# Altium schematic sheet CONNECTOR.SchDoc
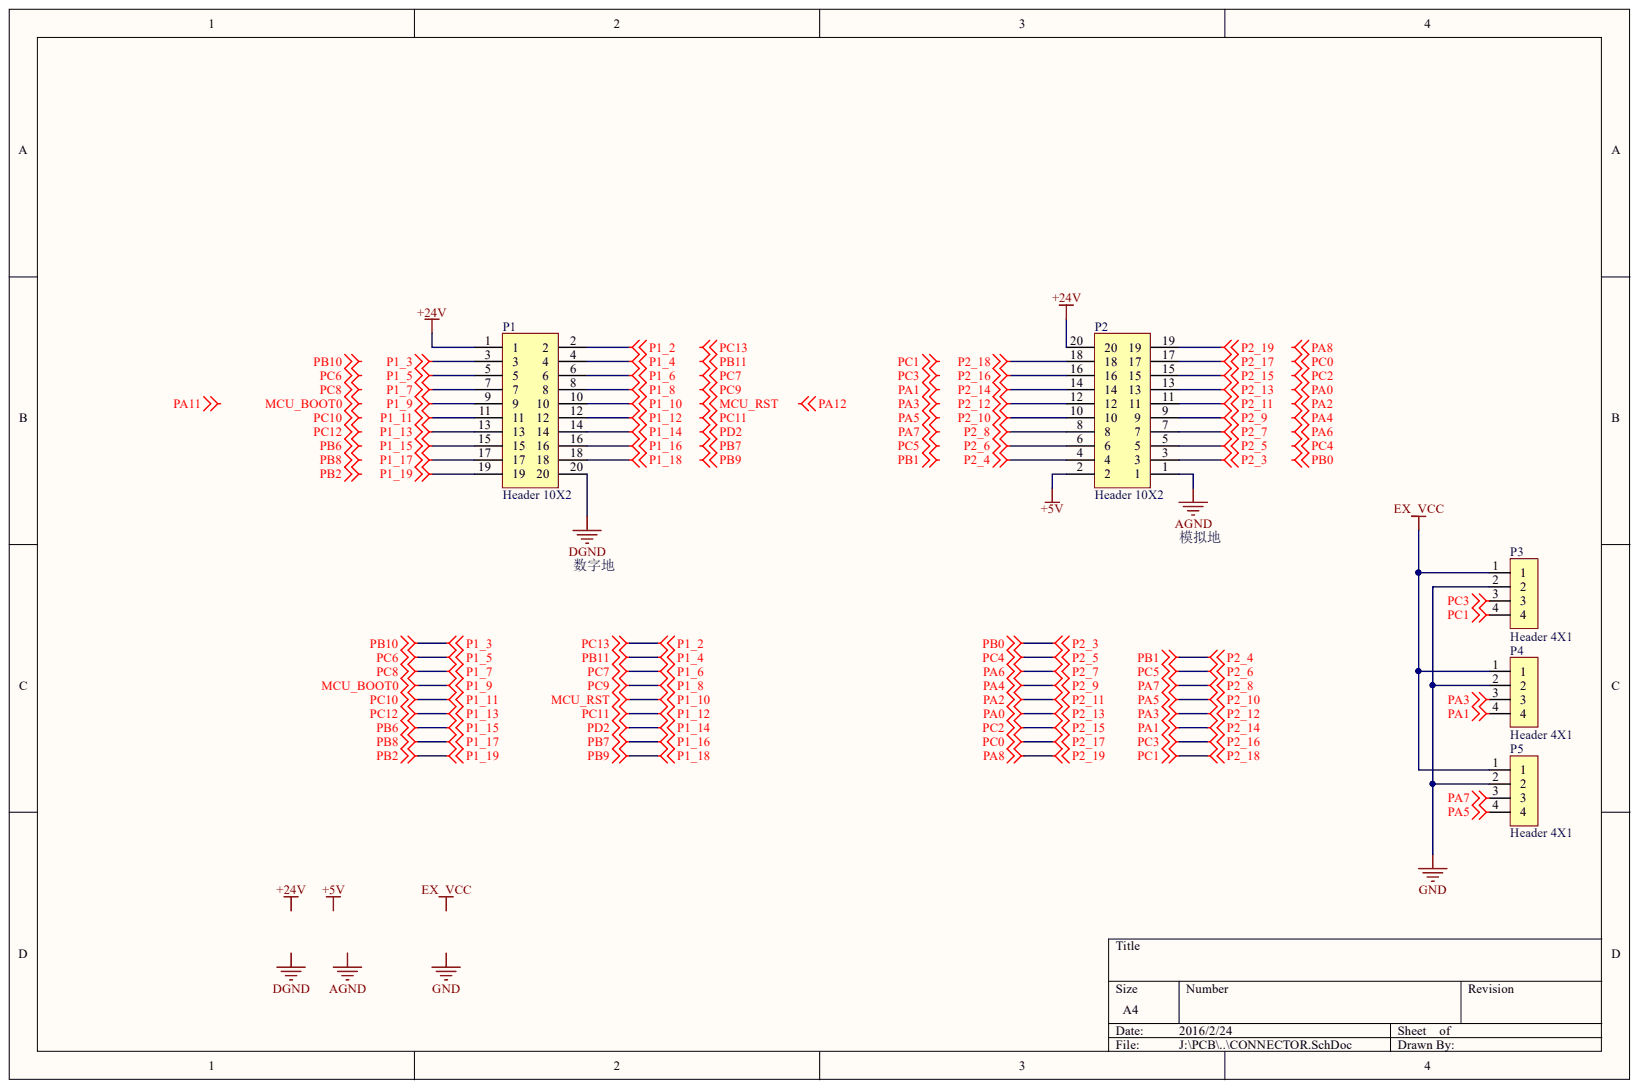
[diagram p0: CONNECTOR.SchDoc]

## Parts
P1  Header 10X2  [Header 2*10]
P2  Header 10X2  [Header 2*10]
P3  Header 4X1  [Header 4X1]
P4  Header 4X1  [Header 4X1]
P5  Header 4X1  [Header 4X1]

## Nets
+5V: P2.2
+24V: P1.1 P2.20
AGND: P2.1
DGND: P1.20
EX_VCC: P3.1 P4.1 P5.1
GND: P3.2 P4.2 P5.2
MCU_BOOT0: P1.9
MCU_RST: P1.10
P1_2: P1.2
P1_3: P1.3
P1_4: P1.4
P1_5: P1.5
P1_6: P1.6
P1_7: P1.7
P1_8: P1.8
P1_11: P1.11
P1_12: P1.12
P1_13: P1.13
P1_14: P1.14
P1_15: P1.15
P1_16: P1.16
P1_17: P1.17
P1_18: P1.18
P1_19: P1.19
P2_3: P2.3
P2_4: P2.4
P2_5: P2.5
P2_6: P2.6
P2_7: P2.7
P2_8: P2.8 P5.3
P2_9: P2.9
P2_10: P2.10 P5.4
P2_11: P2.11
P2_12: P2.12 P4.3
P2_13: P2.13
P2_14: P2.14 P4.4
P2_15: P2.15
P2_16: P2.16 P3.3
P2_17: P2.17
P2_18: P2.18 P3.4
P2_19: P2.19
PA11:
PA12:

## Library data of the parts
P1 P2 = Header 10X2: Component Kind: Standard; Library Name: Connector.SchLib
P3-P5 = Header 4X1: Component Kind: Standard; Description: Header 4X1; Library Name: Connector.SchLib
